# Altium schematic sheet Power.SchDoc(Power)
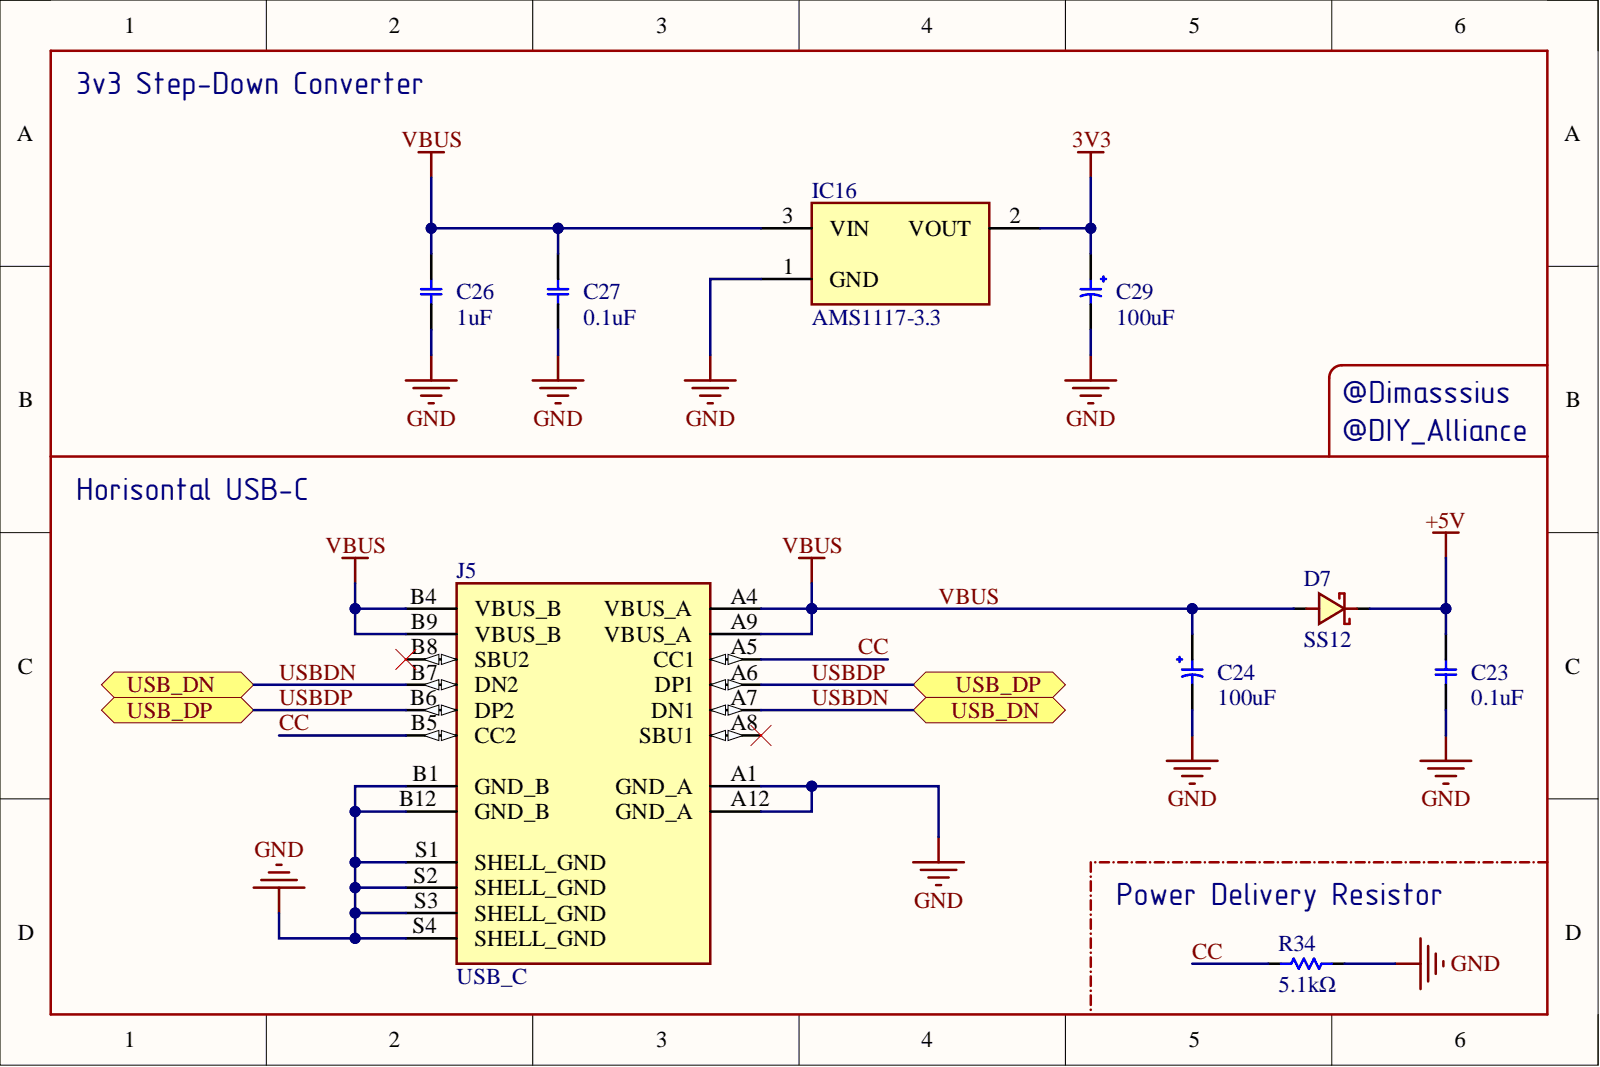
[diagram p0: Power.SchDoc]

## Parts
C23  0.1uF  [CAP_SMD_MLCC_C0603_(M1608)_C0402A_(M1005A)]
C24  100uF  [CAP_SMD_ELEC_ALUM_5MM_H5.4MM]
C26  1uF  [CAP_SMD_MLCC_C0603_(M1608)_C0402A_(M1005A)]
C27  0.1uF  [CAP_SMD_MLCC_C0603_(M1608)_C0402A_(M1005A)]
C29  100uF  [CAP_SMD_ELEC_ALUM_5MM_H5.4MM]
D7  SS12  [DO-214AC]
IC16  AMS1117-3.3  [SOT-89-3]
J5  USB_C  [USB_C_DOUBLE]
R34  5.1kΩ  [RES_SMD_R0603_(M1608)_R0402A_(M1005A)]

## Ports
USB_DN, USB_DP

## Nets
3V3: C29.1 IC16.2
+5V: C23.1 D7.1
GND: C23.2 C24.2 C26.2 C27.2 C29.2 IC16.1 J5.A1(GND_A) J5.A12(GND_A) J5.B1(GND_B) J5.B12(GND_B) J5.S1(SHELL_GND) J5.S2(SHELL_GND) J5.S3(SHELL_GND) J5.S4(SHELL_GND) R34.2
CC: J5.A5(CC1) J5.B5(CC2) R34.1
USBDN: J5.A7(DN1) J5.B7(DN2) ; port USB_DN
USBDP: J5.A6(DP1) J5.B6(DP2) ; port USB_DP
VBUS: C24.1 C26.1 C27.1 D7.2 IC16.3 J5.A4(VBUS_A) J5.A9(VBUS_A) J5.B4(VBUS_B) J5.B9(VBUS_B)

Not connected: J5 A8(SBU1) B8(SBU2)

## Library data of the parts
C23 C27 = CAP_SMD_MLCC_0.1UF_6.3V: Capacitor, Ceramic (MLCC), SMD, 100nF, 6.3V, ±10%, X7R, Multilayer Ceramic Capacitors MLCC - SMD/SMT, ROHS; Manufacturer: Murata Electronics North America; Part Number: LLM315R71C104MA11L; @ qty: 0; Minimum Quantity: 1; Tolerance: ±20%; Unit Price (USD): 0.9; Voltage: 16V; Applications: Bypass, Decoupling; Component Kind: Standard; Datasheets: http://www.murata.com/~/media/webrenewal/support/library/catalog/products/capacitor/mlcc/c02e.pdf; Digi-Key Part Number: 490-4495-1-ND; Factory Stock: 0; Features: Low ESL (Multi-Terminal); Height - Seated (Max): -; Image: //media.digikey.com/Renders/Murata%20Renders/1206(LLM315).jpg; Lead Spacing: -; Lead Style: -; Library Name: Capacitors.SchLib; Mounting Type: Surface Mount, MLCC; Operating Temperature: -55°C ~ 125°C; Package / Case: -; Packaging: Cut Tape (CT); Part Status: Active; Quantity Available: 2915; Ratings: -; Series: LLM; Size / Dimension: -; Temperature Coefficient: X7R; Thickness (Max): -; Value: 0.1uF
C24 C29 = CAP_SMD_ELEC_ALUM_100UF_6.3V: Capacitor, Aluminum Electrolytic Capacitor, SMD, D5xL5.4mm, 100uF, 6.3V, ±20%, Aluminum Electrolytic Capacitors - SMD, ROHS; Component Kind: Standard; Library Name: Capacitors.SchLib; Value: 100uF
C26 = CAP_SMD_MLCC_1UF_6.3V: Capacitor, Ceramic (MLCC), SMD, 1uF, 6.3V, ±10%, X5R, Multilayer Ceramic Capacitors MLCC - SMD/SMT, ROHS; Manufacturer: Murata Electronics North America; Part Number: GRM319R61A105KA01D; @ qty: 0; Minimum Quantity: 1; Unit Price (USD): 0.28; Voltage: 10V; Applications: General Purpose; Component Kind: Standard; Datasheets: http://www.murata.com/~/media/webrenewal/support/library/catalog/products/capacitor/mlcc/c02e.pdf; Digi-Key Part Number: 490-11607-1-ND; Factory Stock: 0; Features: -; Height - Seated (Max): -; Image: //media.digikey.com/Renders/Murata%20Renders/GR331BD72E473KW01L.jpg; Lead Spacing: -; Lead Style: -; Library Name: Capacitors.SchLib; Mounting Type: Surface Mount, MLCC; Operating Temperature: -55°C ~ 85°C; Package / Case: -; Packaging: Cut Tape (CT); Part Status: Active; Quantity Available: 3593; Ratings: -; Series: GRM; Size / Dimension: -; Temperature Coefficient: X5R; Thickness (Max): -; Tolerance: ±10%; Value: 1uF
D7 = SS12: Diode Schottky, SMD, SMA(DO-214AC), 20V, 1A,  500mV@1A, Schottky Diodes, ROHS; Component Kind: Standard; Datasheet Link: https://datasheet.datasheetarchive.com/originals/distributors/Datasheets-24/DSA-463612.pdf; Height: 2.5mm; Library Name: SamacSys.SchLib; Value: SS12
IC16 = AMS1117-3.3: Low Drop Out (LDO) Regulator, SOT-223, SOT-89-3, 72dB@(120Hz), 1A, Fixed 3.3V, Positive electrode, 16V, Voltage Regulators - Linear, Low Drop Out (LDO) Regulators, ROHS; Component Kind: Standard; Datasheet Link: https://datasheet.datasheetarchive.com/originals/distributors/Datasheets-28/DSA-550594.pdf; Height: 1.8mm; Library Name: SamacSys.SchLib; Value: AMS1117-3.3
J5 = USB_C: USB Connector, 5A, USB 3.1, 1 Horizontal Attachment, 16P, Female, -25℃~+85℃, Type-C, SMD, USB Connectors, ROHS; Value: USB 3.1; Component Kind: Standard; Library Name: SamacSys.SchLib
R34 = RES_SMD_5.1KΩ: Resistor, Thick Film Resistor, SMD, 5.1kΩ, ±5%, Chip Resistor - Surface Mount, ROHS; Component Kind: Standard; Library Name: Resistors.SchLib; Value: 5.1kΩ
